# Altium schematic sheet 6Amp_DCDC.SchDoc
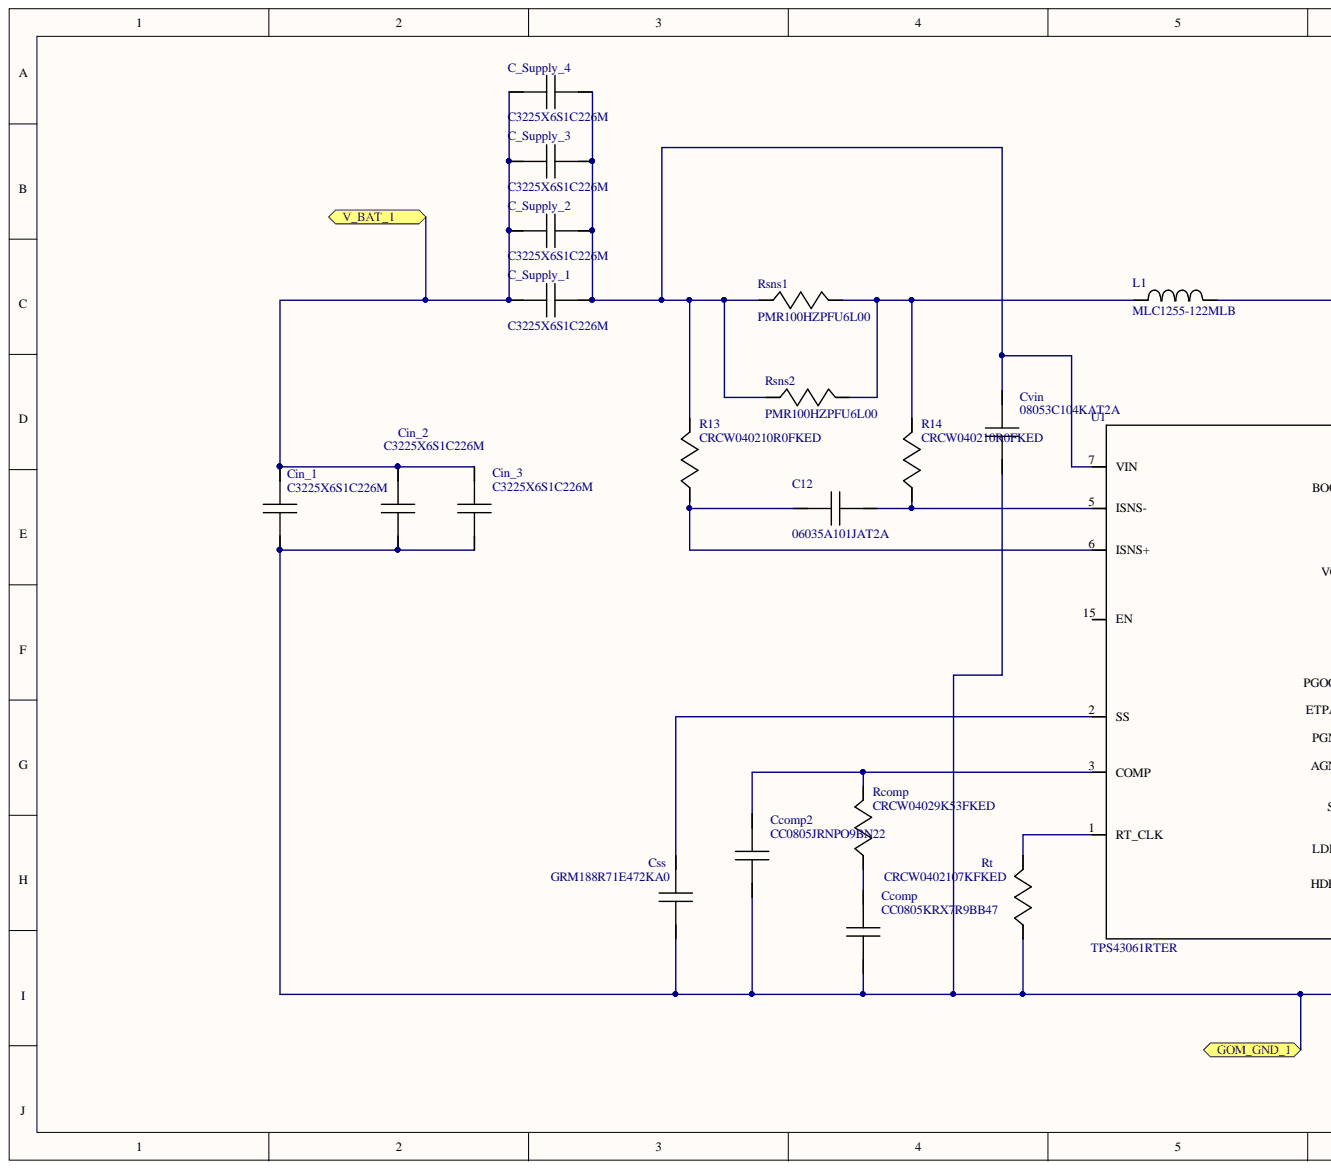
[diagram p0: 6Amp_DCDC.SchDoc, left]
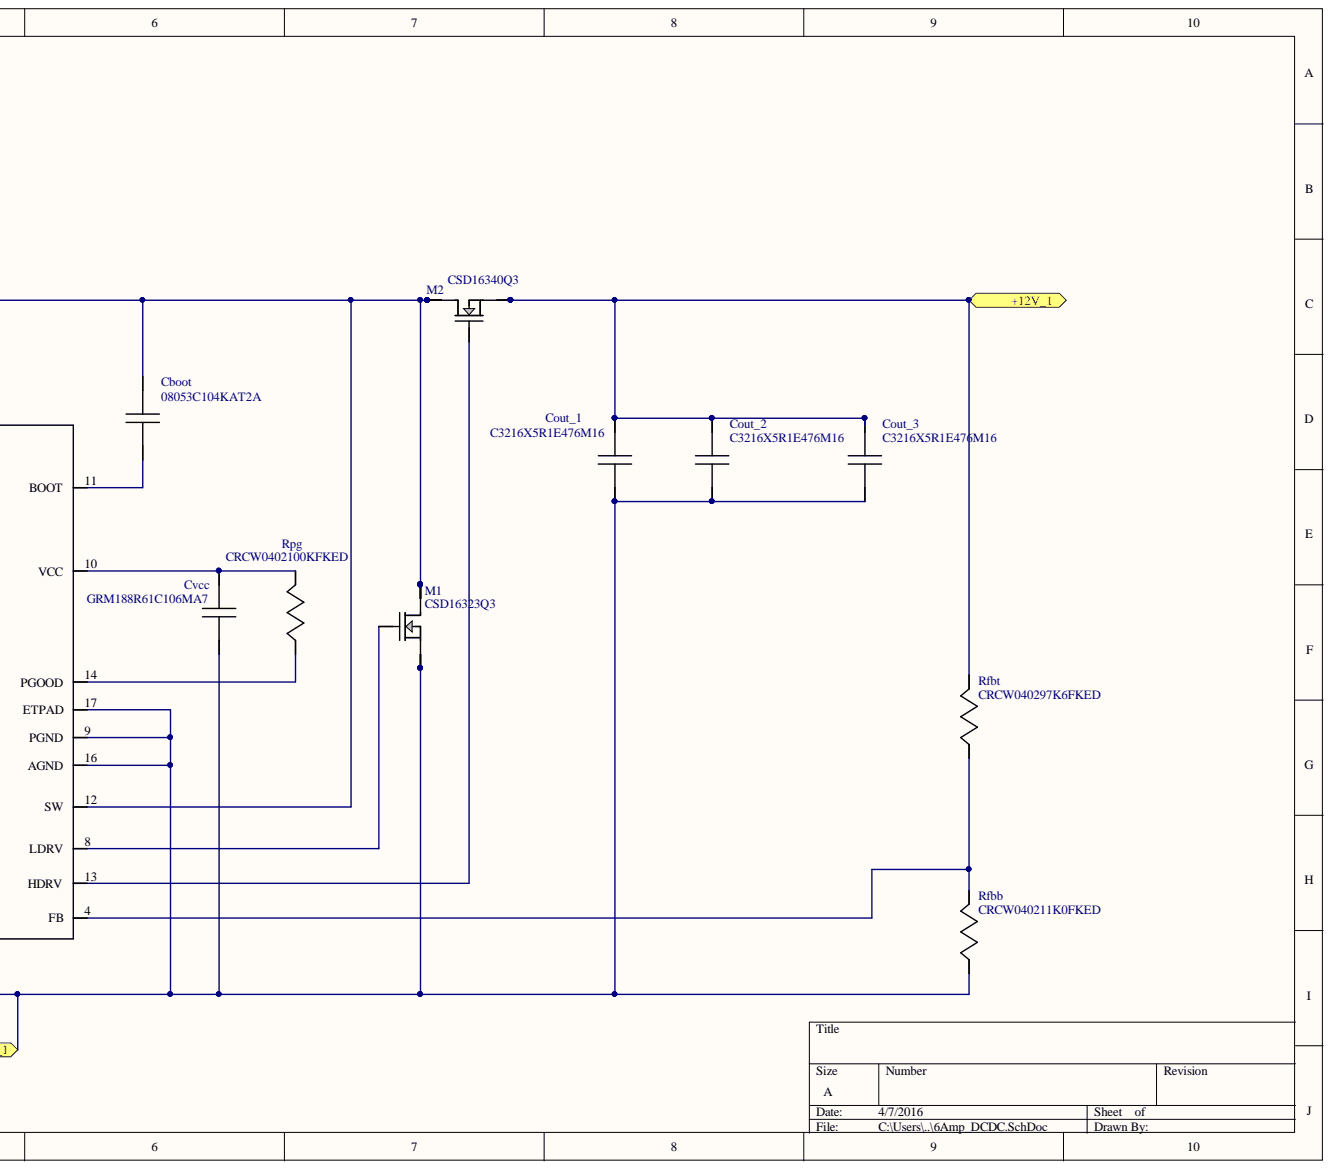
[diagram p1: 6Amp_DCDC.SchDoc, right]

## Parts
C12  06035A101JAT2A  [0603]
C_Supply_1  C3225X6S1C226M  [1210]
C_Supply_2  C3225X6S1C226M  [1210]
C_Supply_3  C3225X6S1C226M  [1210]
C_Supply_4  C3225X6S1C226M  [1210]
Cboot  08053C104KAT2A  [0805]
Ccomp  CC0805KRX7R9BB47  [0805]
Ccomp2  CC0805JRNPO9BN22  [0805]
Cin_1  C3225X6S1C226M  [1210]
Cin_2  C3225X6S1C226M  [1210]
Cin_3  C3225X6S1C226M  [1210]
Cout_1  C3216X5R1E476M16  [1206_190]
Cout_2  C3216X5R1E476M16  [1206_190]
Cout_3  C3216X5R1E476M16  [1206_190]
Css  GRM188R71E472KA0  [0603]
Cvcc  GRM188R61C106MA7  [0603]
Cvin  08053C104KAT2A  [0805]
L1  MLC1255-122MLB  [MLC1255]
M1  CSD16323Q3  [TRANS_NexFET_Q3]
M2  CSD16340Q3  [TRANS_NexFET_Q3]
R13  CRCW040210R0FKED  [0402]
R14  CRCW040210R0FKED  [0402]
Rcomp  CRCW04029K53FKED  [0402]
Rfbb  CRCW040211K0FKED  [0402]
Rfbt  CRCW040297K6FKED  [0402]
Rpg  CRCW0402100KFKED  [0402]
Rsns1  PMR100HZPFU6L00  [2512]
Rsns2  PMR100HZPFU6L00  [2512]
Rt  CRCW0402107KFKED  [0402]
U1  TPS43061RTER  [S-PVQFN-N16]

## Ports
+12V_1, GOM_GND_1, V_BAT_1

## Nets
+12V_1: Cout_1.1 Cout_2.1 Cout_3.1 M2.1 M2.2 M2.3 Rfbt.1 ; port +12V_1
GOM_GND_1: Ccomp.2 Ccomp2.2 Cin_1.2 Cin_2.2 Cin_3.2 Cout_1.2 Cout_2.2 Cout_3.2 Css.1 Cvcc.2 Cvin.2 M1.1 M1.2 M1.3 Rfbb.2 Rt.2 U1.9(PGND) U1.16(AGND) U1.17(ETPAD) ; port GOM_GND_1
V_BAT_1: C_Supply_1.1 C_Supply_2.1 C_Supply_3.1 C_Supply_4.1 Cin_1.1 Cin_2.1 Cin_3.1 ; port V_BAT_1
NetC12_1: C12.1 R14.2 U1.5(ISNS-)
NetC12_2: C12.2 R13.2 U1.6(ISNS+)
NetC_Supply_1_2: C_Supply_1.2 C_Supply_2.2 C_Supply_3.2 C_Supply_4.2 Cvin.1 R13.1 Rsns1.2 Rsns2.2 U1.7(VIN)
NetCboot_1: Cboot.1 L1.2 M1.5 M1.6 M1.7 M1.8 M1.9 M2.5 M2.6 M2.7 M2.8 M2.9 U1.12(SW)
NetCboot_2: Cboot.2 U1.11(BOOT)
NetCcomp2_1: Ccomp2.1 Rcomp.1 U1.3(COMP)
NetCcomp_1: Ccomp.1 Rcomp.2
NetCss_2: Css.2 U1.2(SS)
NetCvcc_1: Cvcc.1 Rpg.1 U1.10(VCC)
NetL1_1: L1.1 R14.1 Rsns1.1 Rsns2.1
NetM1_4: M1.4 U1.8(LDRV)
NetM2_4: M2.4 U1.13(HDRV)
NetRfbb_1: Rfbb.1 Rfbt.2 U1.4(FB)
NetRpg_2: Rpg.2 U1.14(PGOOD)
NetRt_1: Rt.1 U1.1(RT_CLK)

Not connected: U1 15(EN)

## Library data of the parts
C12 = 06035A101JAT2A: Imported; Manufacturer_Name: AVX; Value: 100pF; Component Kind: Standard; Library Name: 2016-03-26_18-23-46.SchLib; Manufacturer_Part_Number: 06035A101JAT2A; RefDes: RefDes; TYPE: TYPE; Vendor: AVX
C_Supply_1-C_Supply_4 = C3225X6S1C226M: 100mF; Manufacturer_Name: TDK; Value: 22uF; Component Kind: Standard; Library Name: 2016-03-26_18-23-46.SchLib; Manufacturer_Part_Number: C3225X6S1C226M; RefDes: RefDes; TYPE: TYPE; Vendor: TDK
Cboot Cvin = 08053C104KAT2A: Imported; Manufacturer_Name: AVX; Value: 100nF; Component Kind: Standard; Library Name: 2016-03-26_18-23-46.SchLib; Manufacturer_Part_Number: 08053C104KAT2A; RefDes: RefDes; TYPE: TYPE; Vendor: AVX
Ccomp = CC0805KRX7R9BB47: Imported; Manufacturer_Name: Yageo America; Manufacturer_Part_Number: CC0805KRX7R9BB472; Value: 4.7nF; Component Kind: Standard; Library Name: 2016-03-26_18-23-46.SchLib; RefDes: RefDes; TYPE: TYPE; Vendor: Yageo America
Ccomp2 = CC0805JRNPO9BN22: Imported; Manufacturer_Name: Yageo America; Manufacturer_Part_Number: CC0805JRNPO9BN221; Value: 220pF; Component Kind: Standard; Library Name: 2016-03-26_18-23-46.SchLib; RefDes: RefDes; TYPE: TYPE; Vendor: Yageo America
Cin_1-Cin_3 = C3225X6S1C226M: Imported; Manufacturer_Name: TDK; Value: 22uF; Component Kind: Standard; Library Name: 2016-03-26_18-23-46.SchLib; Manufacturer_Part_Number: C3225X6S1C226M; RefDes: RefDes; TYPE: TYPE; Vendor: TDK
Cout_1-Cout_3 = C3216X5R1E476M16: Imported; Manufacturer_Name: TDK; Manufacturer_Part_Number: C3216X5R1E476M160AC; Cap_F: 0.000047; Value: 47uF; VDC_V: 25; Component Kind: Standard; Library Name: 2016-03-26_18-23-46.SchLib; MountType: SMT; RefDes: RefDes; RoHS: Y; TYPE: TYPE
Css = GRM188R71E472KA0: Imported; Manufacturer_Name: MuRata; Manufacturer_Part_Number: GRM188R71E472KA01D; Value: 4.7nF; Component Kind: Standard; Library Name: 2016-03-26_18-23-46.SchLib; RefDes: RefDes; TYPE: TYPE; Vendor: MuRata
Cvcc = GRM188R61C106MA7: Imported; Manufacturer_Name: MuRata; Manufacturer_Part_Number: GRM188R61C106MA73D; Cap: 1.0E-5; ESR: 0; Value: 10uF; VDC: 16; Component Kind: Standard; IRMS: 0; Library Name: 2016-03-26_18-23-46.SchLib; RefDes: RefDes; TYPE: TYPE; Vendor: Murata
L1 = MLC1255-122MLB: Imported; Manufacturer_Name: Coilcraft; Value: 1.2uH; Component Kind: Standard; Library Name: 2016-03-26_18-23-46.SchLib; Manufacturer_Part_Number: MLC1255-122MLB; RefDes: RefDes; TYPE: TYPE; Vendor: Coilcraft
M1 = CSD16323Q3: Imported; Manufacturer_Name: Texas Instruments; Value: 25V; Component Kind: Standard; Library Name: 2016-03-26_18-23-46.SchLib; Manufacturer_Part_Number: CSD16323Q3; RefDes: RefDes; TYPE: TYPE; Vendor: Texas Instruments
M2 = CSD16340Q3: Imported; Manufacturer_Name: Texas Instruments; Value: 25V; Component Kind: Standard; Library Name: 2016-03-26_18-23-46.SchLib; Manufacturer_Part_Number: CSD16340Q3; RefDes: RefDes; TYPE: TYPE; Vendor: Texas Instruments
R13 R14 = CRCW040210R0FKED: Imported; Manufacturer_Name: Vishay-Dale; Value: 10; Component Kind: Standard; Library Name: 2016-03-26_18-23-46.SchLib; Manufacturer_Part_Number: CRCW040210R0FKED; RefDes: RefDes; TYPE: TYPE; Vendor: Vishay-Dale
Rcomp = CRCW04029K53FKED: Imported; Manufacturer_Name: Vishay-Dale; Value: 9.53k; Component Kind: Standard; Library Name: 2016-03-26_18-23-46.SchLib; Manufacturer_Part_Number: CRCW04029K53FKED; RefDes: RefDes; TYPE: TYPE; Vendor: Vishay-Dale
Rfbb = CRCW040211K0FKED: Imported; Manufacturer_Name: Vishay-Dale; Value: 11k; Component Kind: Standard; Library Name: 2016-03-26_18-23-46.SchLib; Manufacturer_Part_Number: CRCW040211K0FKED; RefDes: RefDes; TYPE: TYPE; Vendor: Vishay-Dale
Rfbt = CRCW040297K6FKED: Imported; Manufacturer_Name: Vishay-Dale; Value: 97.6k; Component Kind: Standard; Library Name: 2016-03-26_18-23-46.SchLib; Manufacturer_Part_Number: CRCW040297K6FKED; RefDes: RefDes; TYPE: TYPE; Vendor: Vishay-Dale
Rpg = CRCW0402100KFKED: Imported; Manufacturer_Name: Vishay-Dale; Value: 100k; Component Kind: Standard; Library Name: 2016-03-26_18-23-46.SchLib; Manufacturer_Part_Number: CRCW0402100KFKED; RefDes: RefDes; TYPE: TYPE; Vendor: Vishay-Dale
Rsns1 Rsns2 = PMR100HZPFU6L00: Imported; Manufacturer_Name: Rohm; Value: 6m; Component Kind: Standard; Library Name: 2016-03-26_18-23-46.SchLib; Manufacturer_Part_Number: PMR100HZPFU6L00; RefDes: RefDes; TYPE: TYPE; Vendor: Rohm
Rt = CRCW0402107KFKED: Imported; Manufacturer_Name: Vishay-Dale; Value: 107k; Component Kind: Standard; Library Name: 2016-03-26_18-23-46.SchLib; Manufacturer_Part_Number: CRCW0402107KFKED; RefDes: RefDes; TYPE: TYPE; Vendor: Vishay-Dale
U1 = TPS43061RTER: Imported; Manufacturer_Name: Texas Instruments; Component Kind: Standard; Library Name: 2016-03-26_18-23-46.SchLib; Manufacturer_Part_Number: TPS43061RTER; RefDes: RefDes; TYPE: TYPE; Value: Value; Vendor: Texas Instruments
